# Altium schematic sheet Neopixels.SchDoc(NEO32)
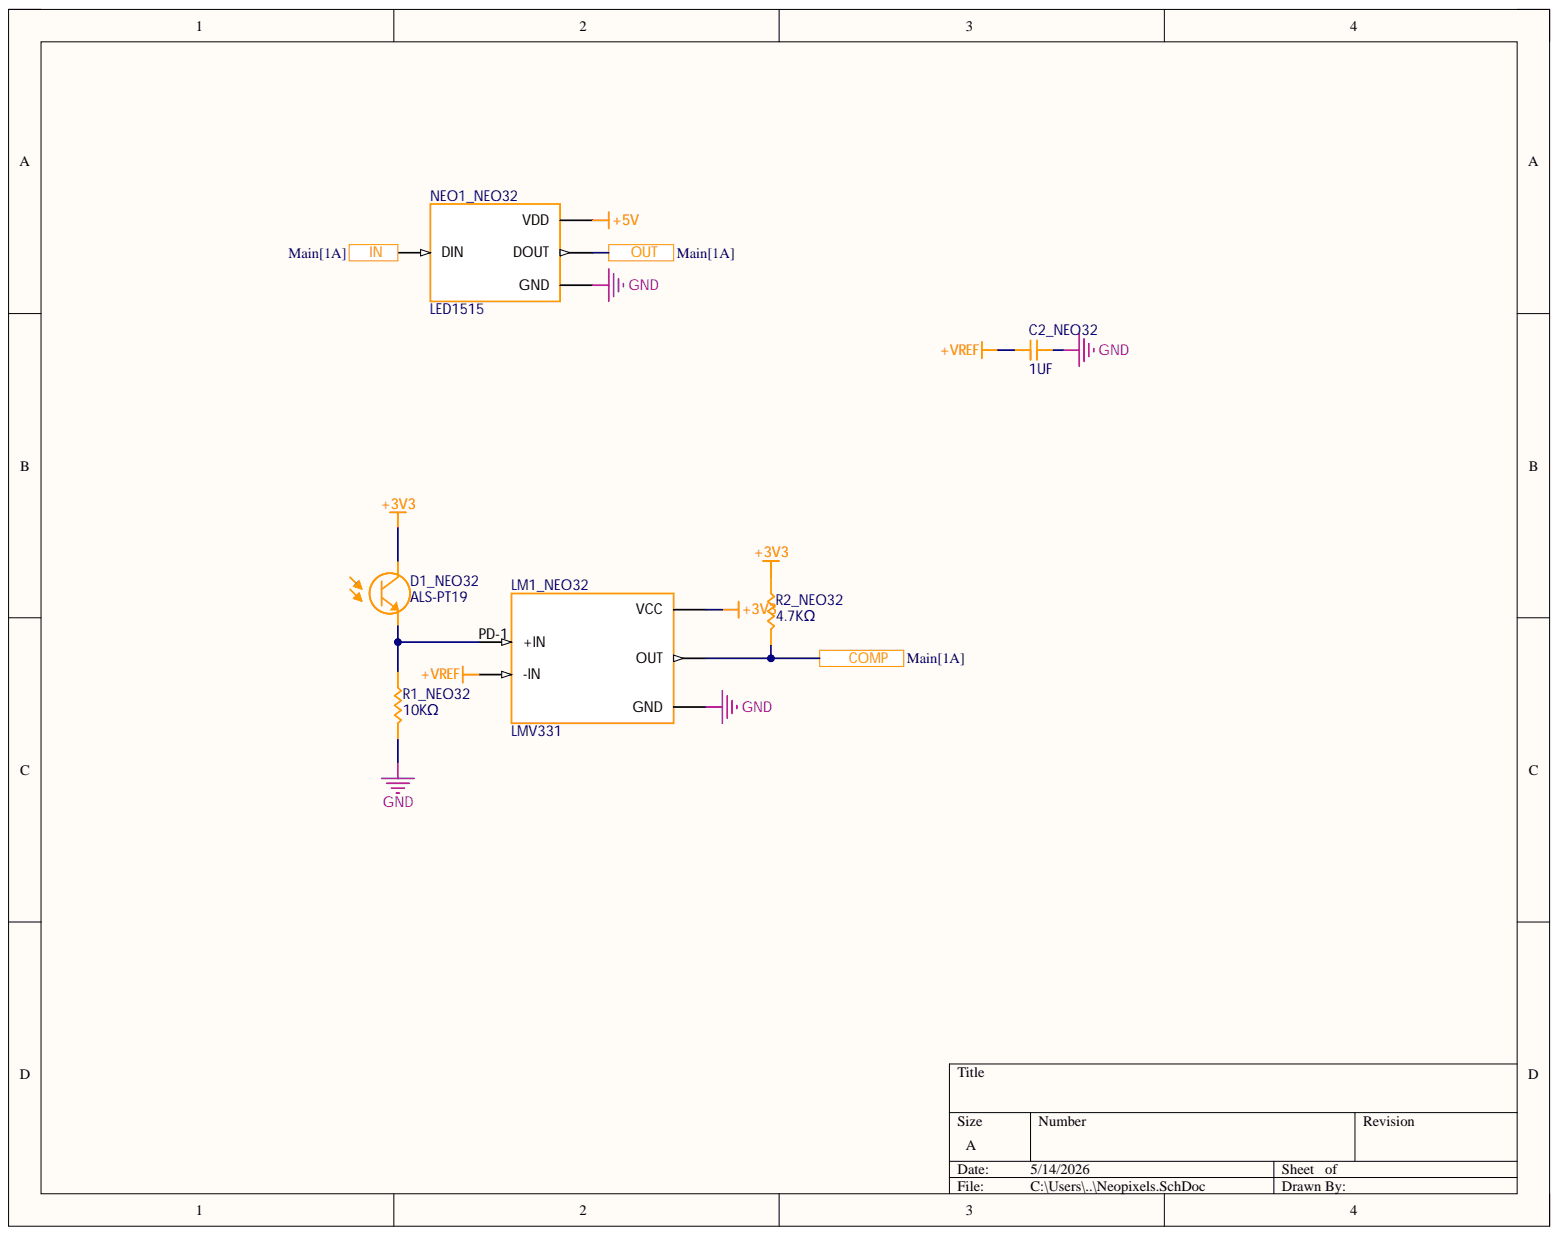
[diagram p0: Neopixels.SchDoc]

## Parts
C2_NEO32  1UF  [CAP_0603]
D1_NEO32  ALS-PT19  [ALS-PT19]
LM1_NEO32  LMV331  [LMV331]
NEO1_NEO32  LED1515  [LED1515]
R1_NEO32  10KΩ  [RES_0603]
R2_NEO32  4.7KΩ  [RES_0603]

## Ports
COMP_NEO32, IN_NEO32, OUT_NEO32

## Nets
+3V3: D1_NEO32.C LM1_NEO32.5(VCC) R2_NEO32.2
+5V: NEO1_NEO32.VDD
+VREF: C2_NEO32.2 LM1_NEO32.3(-IN)
GND: C2_NEO32.1 LM1_NEO32.2(GND) NEO1_NEO32.GND R1_NEO32.1
PD-1_NEO32: D1_NEO32.E LM1_NEO32.1(+IN) R1_NEO32.2  [also labelled PD-1]
NetLM1_NEO32_4: LM1_NEO32.4(OUT) R2_NEO32.1 ; port COMP_NEO32
NetNEO1_NEO32_DIN: NEO1_NEO32.DIN ; port IN_NEO32
NetNEO1_NEO32_DOUT: NEO1_NEO32.DOUT ; port OUT_NEO32

## Library data of the parts
C2_NEO32 = CAP_0603: Capacitor SMD 0603 generic; Component Kind: Standard; Library Name: RC.IntLib
R1_NEO32 R2_NEO32 = RES_0603: Resistor SMD 0603 generic; Component Kind: Standard; Library Name: GenericRC.SchLib
D1_NEO32 = ALS-PT19: Component Kind: Standard; Library Name: RC.IntLib
LM1_NEO32 = LMV331: Component Kind: Standard; Library Name: RC.IntLib
NEO1_NEO32 = LED1515: Component Kind: Standard; Library Name: RC.IntLib
